# Altium schematic sheet 04_Peripherals.SchDoc(04_Peripherals)
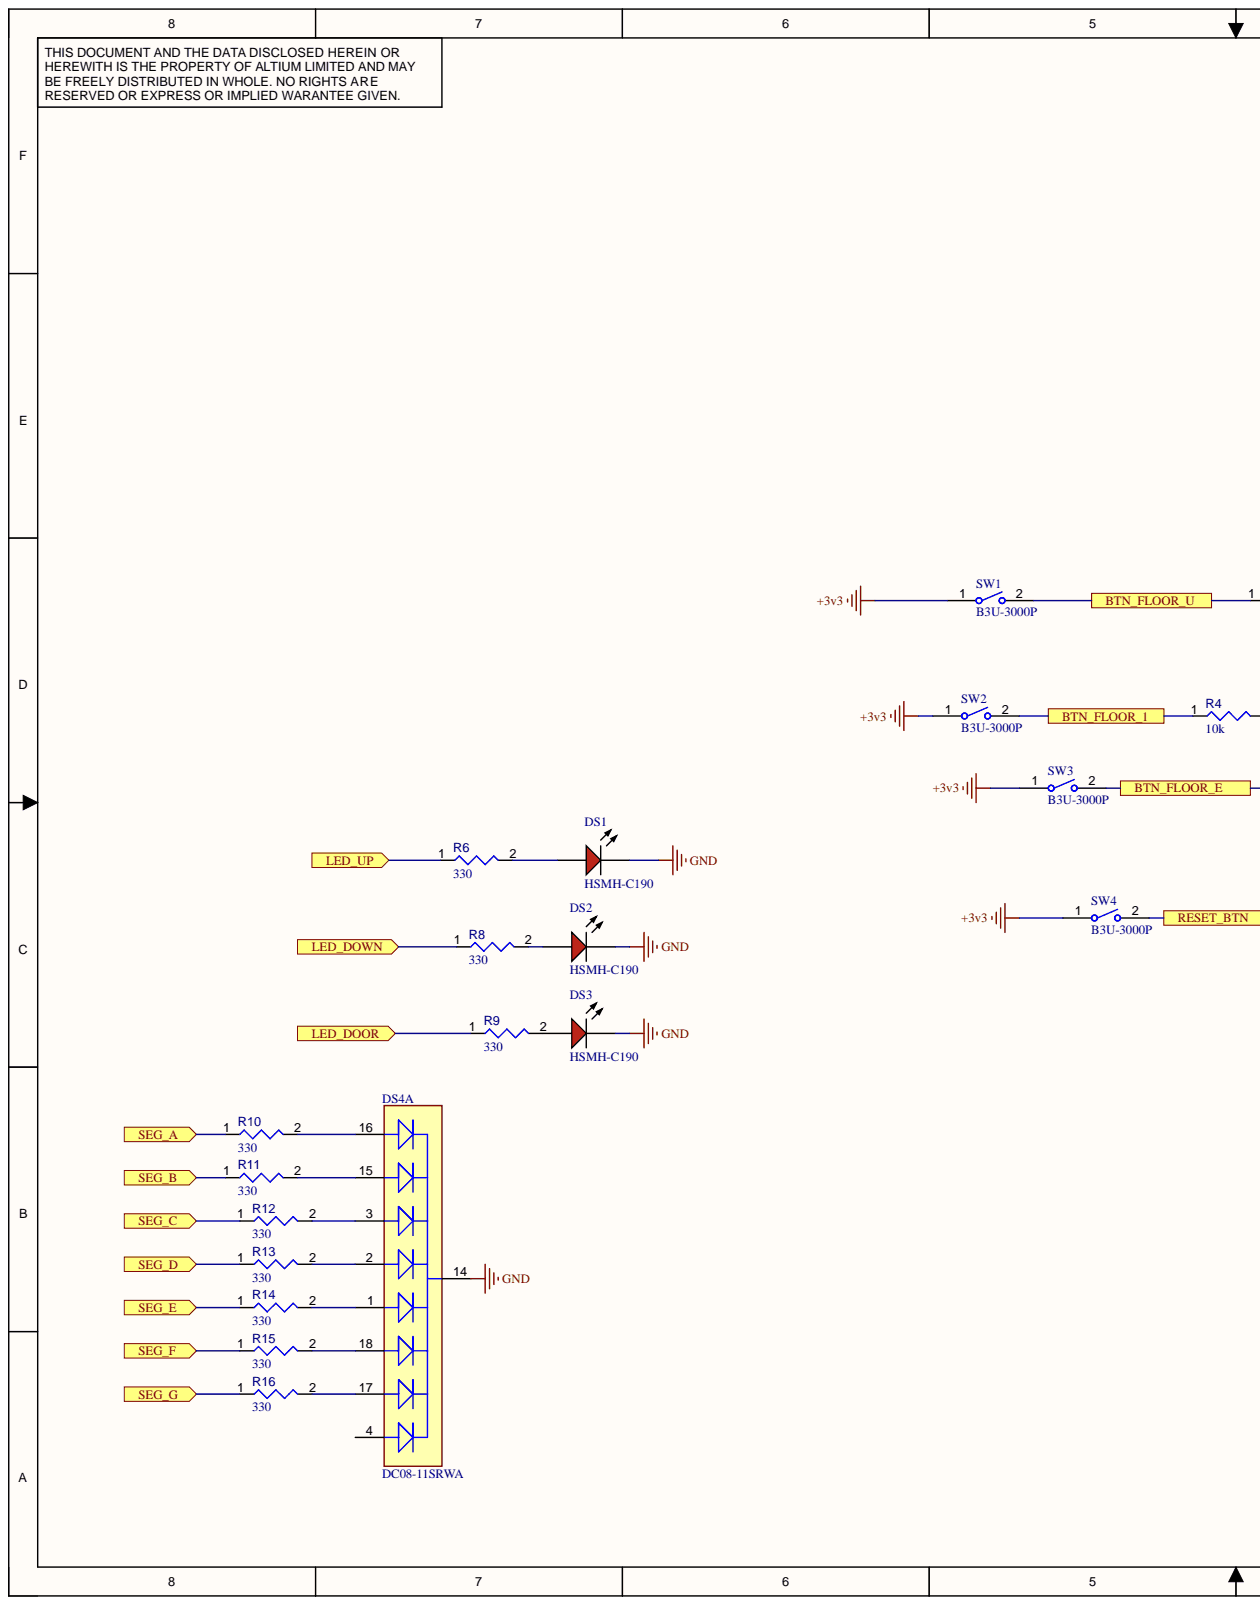
[diagram p0: 04_Peripherals.SchDoc, left]
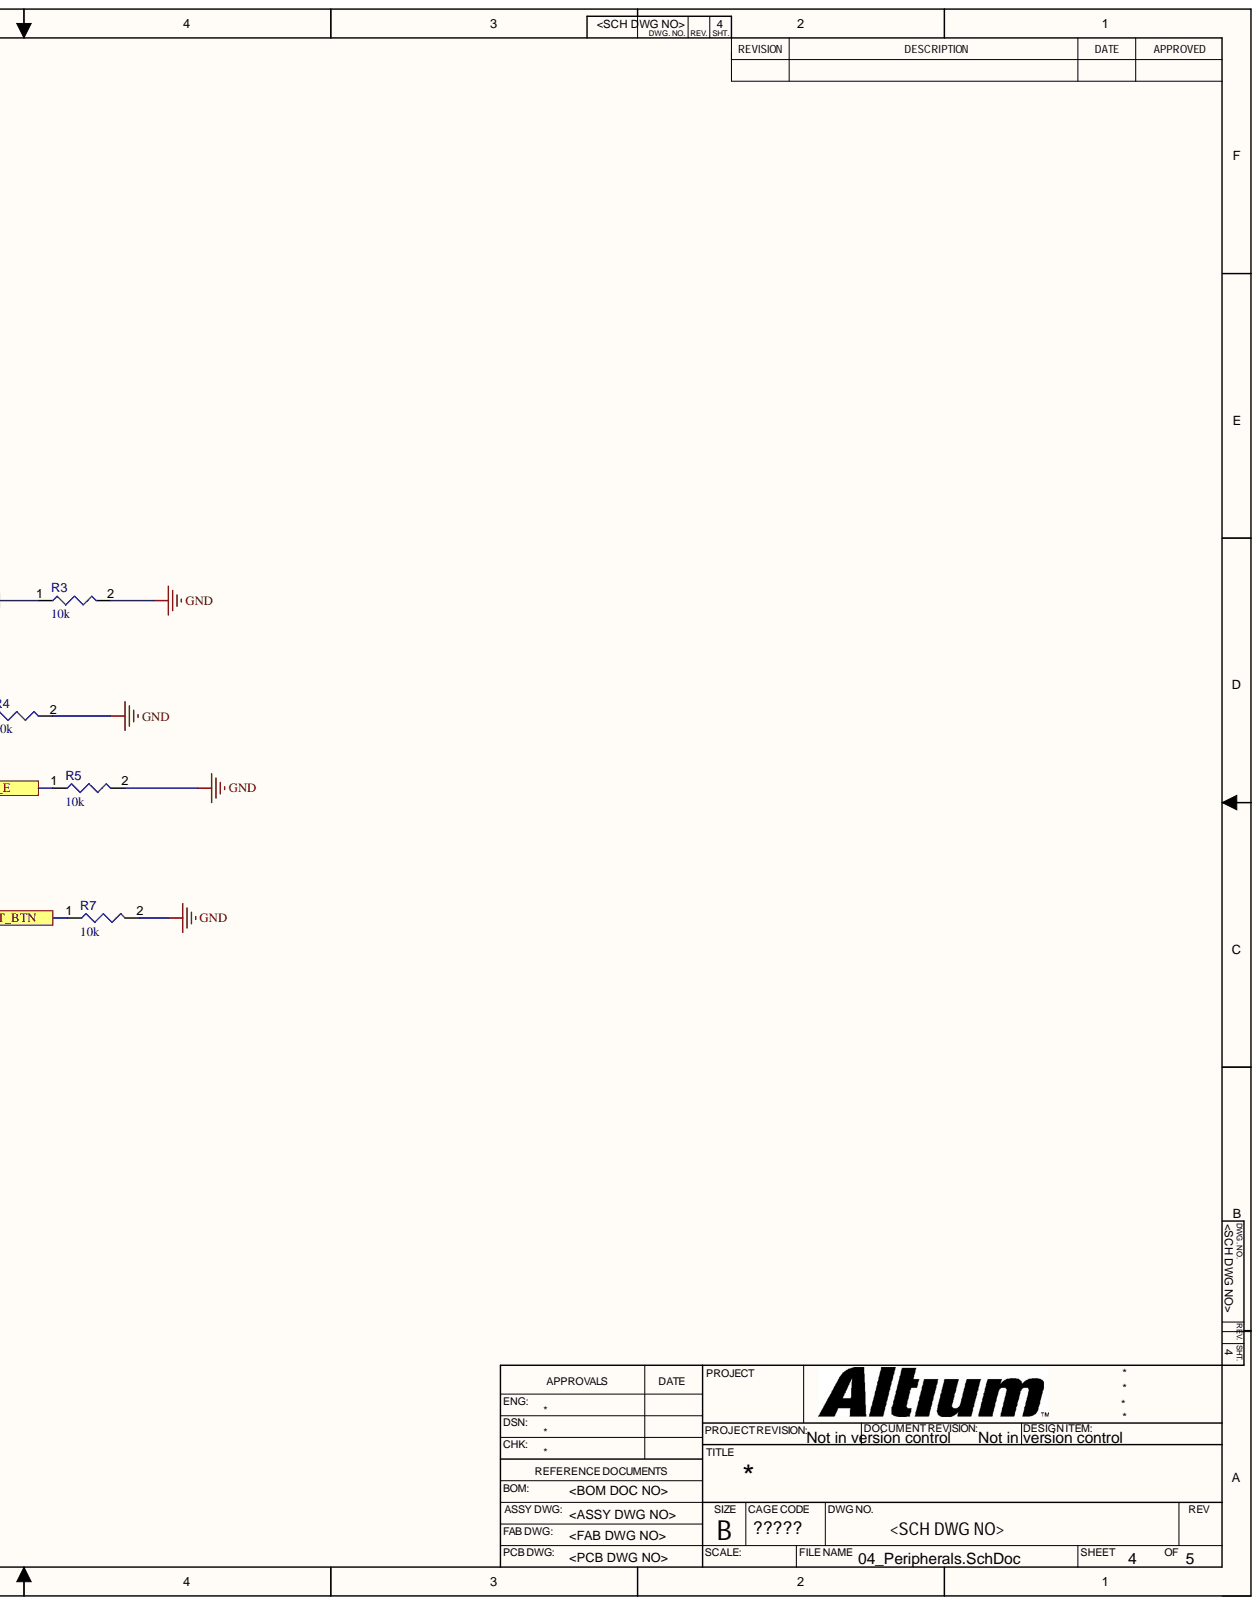
[diagram p1: 04_Peripherals.SchDoc, right]

## Parts
DS1  HSMH-C190  [AVAG-HSMX-C190_V]
DS2  HSMH-C190  [AVAG-HSMX-C190_V]
DS3  HSMH-C190  [AVAG-HSMX-C190_V]
DS4  DC08-11SRWA  [FP-DC08-11SRWA-MFG]  (part A)
R3  value 10k  [RESC3216X60X45ML15T20]  (hidden comment: Resistor 10k +/-1% 1206 250 mW)
R4  value 10k  [RESC3216X60X45ML15T20]  (hidden comment: Resistor 10k +/-1% 1206 250 mW)
R5  value 10k  [RESC3216X60X45ML15T20]  (hidden comment: Resistor 10k +/-1% 1206 250 mW)
R6  value 330  [RESC0402(1005)_L]  (hidden comment: Resistor 330R +/-5 % 0402 63 mW)
R7  value 10k  [RESC3216X60X45ML15T20]  (hidden comment: Resistor 10k +/-1% 1206 250 mW)
R8  value 330  [RESC0402(1005)_L]  (hidden comment: Resistor 330R +/-5 % 0402 63 mW)
R9  value 330  [RESC0402(1005)_L]  (hidden comment: Resistor 330R +/-5 % 0402 63 mW)
R10  value 330  [RESC0402(1005)_L]  (hidden comment: 330R2J)
R11  value 330  [RESC0402(1005)_L]  (hidden comment: 330R2J)
R12  value 330  [RESC0402(1005)_L]  (hidden comment: 330R2J)
R13  value 330  [RESC0402(1005)_L]  (hidden comment: 330R2J)
R14  value 330  [RESC0402(1005)_L]  (hidden comment: 330R2J)
R15  value 330  [RESC0402(1005)_L]  (hidden comment: 330R2J)
R16  value 330  [RESC0402(1005)_L]  (hidden comment: 330R2J)
SW1  B3U-3000P  [OMRON-B3U-3000P_V]
SW2  B3U-3000P  [OMRON-B3U-3000P_V]
SW3  B3U-3000P  [OMRON-B3U-3000P_V]
SW4  B3U-3000P  [OMRON-B3U-3000P_V]

## Ports
BTN_FLOOR_1, BTN_FLOOR_E, BTN_FLOOR_U, LED_DOOR, LED_DOWN, LED_UP, RESET_BTN, SEG_A, SEG_B, SEG_C, SEG_D, SEG_E, SEG_F, SEG_G

## Nets
+3v3: SW1.1 SW2.1 SW3.1 SW4.1
GND: DS1.2 DS2.2 DS3.2 DS4.14 R3.2 R4.2 R5.2 R7.2
BTN_FLOOR_1: R4.1 SW2.2 ; port BTN_FLOOR_1
BTN_FLOOR_E: R5.1 SW3.2 ; port BTN_FLOOR_E
BTN_FLOOR_U: R3.1 SW1.2 ; port BTN_FLOOR_U
LED_DOOR: R9.1 ; port LED_DOOR
LED_DOWN: R8.1 ; port LED_DOWN
LED_UP: R6.1 ; port LED_UP
RESET_BTN: R7.1 SW4.2 ; port RESET_BTN
SEG_A: R10.1 ; port SEG_A
SEG_B: R11.1 ; port SEG_B
SEG_C: R12.1 ; port SEG_C
SEG_D: R13.1 ; port SEG_D
SEG_E: R14.1 ; port SEG_E
SEG_F: R15.1 ; port SEG_F
SEG_G: R16.1 ; port SEG_G
NetDS1_1: DS1.1 R6.2
NetDS2_1: DS2.1 R8.2
NetDS3_1: DS3.1 R9.2
NetDS4_1: DS4.1 R14.2
NetDS4_2: DS4.2 R13.2
NetDS4_3: DS4.3 R12.2
NetDS4_15: DS4.15 R11.2
NetDS4_16: DS4.16 R10.2
NetDS4_17: DS4.17 R16.2
NetDS4_18: DS4.18 R15.2

Not connected: DS4 4

## Library data of the parts
DS1-DS3 = CMP-007-00014-2: LED Uni-Color Red, 65 mW, 20 mA, -40 to 85 degC, 2-Pin SMD, RoHS, Tape and Reel; Manufacturer: Avago; Forward Current: 20mA; Forward Voltage: 1.8V; Lens Style: Diffused; Luminous Intensity: 17mcd; Power: 78mW; Viewing Angle: 170degrees; Case/Package: 0603; Colour: Red; Component Kind: Standard; Datasheet URL: http://docs.avagotech.com/docs/AV02-0551EN; Datasheet Version: Mar-12; Illumination Colour: Red; Manufacturer URL: http://www.avagotech.com/; Max Operating Temperature: 85°C; Min Operating Temperature: -40°C; Mounting Technology: SM; Nominal Vf: 1.8V; Package Description: 2-Pin Surface Mount Device, Body 1.6 x 0.8 mm, Height 0.9 mm; Package Quantity: 4000; Package Reference: HSMX-C190; Packaging: TapeandReel; Pins: 2; RoHS Compliant: True; Library Name: Oluwasholape-daniel Oyemade
DS4 = CMP-44525-000001-1: DISPLAY 660NM RED 2DIG 0.8" 7SEG; Manufacturer: Kingbright; Display Type: LED; Element Config: Common Cathode; Forward Current: 20mA; Forward Voltage: 1.85V; Luminous Intensity: 32mcd; Power Dissipation: 75mW; Reverse Voltage: 5V; Wavelength: 640nm; Automotive: No; Category: Disp; Component Kind: Standard; ComponentLink2Description: Datasheet; ComponentLink2URL: https://www.kingbrightusa.com/images/catalog/SPEC/DC08-11SRWA.pdf; Device Class L1: Optoelectronics; Device Class L2: Displays; Device Class L3: LED Displays; Digikey Description: DISPLAY 660NM RED 2DIG 0.8" 7SEG; Height: 10.25mm; Lead Free: Yes; Library Name: Altium Content Vault; Manufacturer Part Number: DC08-11SRWA; Mouser Description: LED Displays & Accessories Dual Red 640nm Common Cathode; Octopart: https://octopart.com/dc08-11srwa-kingbright-55457135; Package: DC08-11SRWA; RoHS: TRUE; Temperature: -40°C to +85°C; Temperature Range High: +85°C; Temperature Range Low: -40°C; Test Current: 20mA
R3-R5 R7 = CMP-009-00016-5: Chip Resistor, 10 KOhm, +/- 1%, 0.25 W, -55 to 155 degC, 1206 (3216 Metric); Power: 250mW; Voltage Rating: 200V; Case/Package: 1206; Component Kind: Standard; Max Operating Temperature: 155°C; Min Operating Temperature: -55°C; Mounting Technology: SM; Pins: 2; RoHS Compliant: True; Tolerance: 1%; Library Name: Oluwasholape-daniel Oyemade
R6 R8 R9 = CMP-009-00132-3: Chip Resistor, 330 Ohms, +/-5 %, 63 mW, -55 to 155 degC, 0402; Power: 63mW; Tolerance: 5%; Case/Package: 0402; Component Kind: Standard; Max Operating Temperature: 155°C; Min Operating Temperature: -55°C; Pins: 2; Library Name: Oluwasholape-daniel Oyemade
R10-R16 = CMP-009-00095-2: 330R 0.063W 5% 0402 (1005 Metric)  SMD; Power: 63mW; Case/Package: 0402; Component Kind: Standard; Mounting Technology: SMT; Pins: 2; Tolerance: 5%; Library Name: Oluwasholape-daniel Oyemade
SW1-SW4 = CMP-011-00005-2: Tactile Switch, SPST-NO, 0.05 A, 12 V, -25 to 70 degC, 2-Pin SMD, RoHS, Tape and Reel; Manufacturer: Omron Electronic Components; Contact Current Rating: 50mA; IP Rating: IP40; Max Contact Resistance: 100mohm; Max Voltage Rating (DC): 12V; Operating Force: 162gf; Throw Configuration: SPST; Case/Package: B3U-3000P; Component Kind: Standard; Contact Material: Silver; Current Rating: 50mA; Datasheet URL: https://www.omron.com/ecb/products/pdf/en-b3u.pdf; Datasheet Version: Jan-15; Manufacturer URL: http://www.omron.com/; Max Current Rating: 50mA; Max Operating Temperature: 70°C; Min Operating Temperature: -25°C; Mounting Technology: SM; Package Description: 2-Pin Surface Mount Device, Body 4 x 3.2 mm; Package Quantity: 4000; Package Reference: B3U-3000P; Packaging: TapeandReel; RoHS Compliant: True; Voltage Rating: 12V; Voltage Rating (DC): 12V; Library Name: Oluwasholape-daniel Oyemade
